# Altium schematic sheet REUN.468349.001_PSU_Board_3V3_Logic.SchDoc
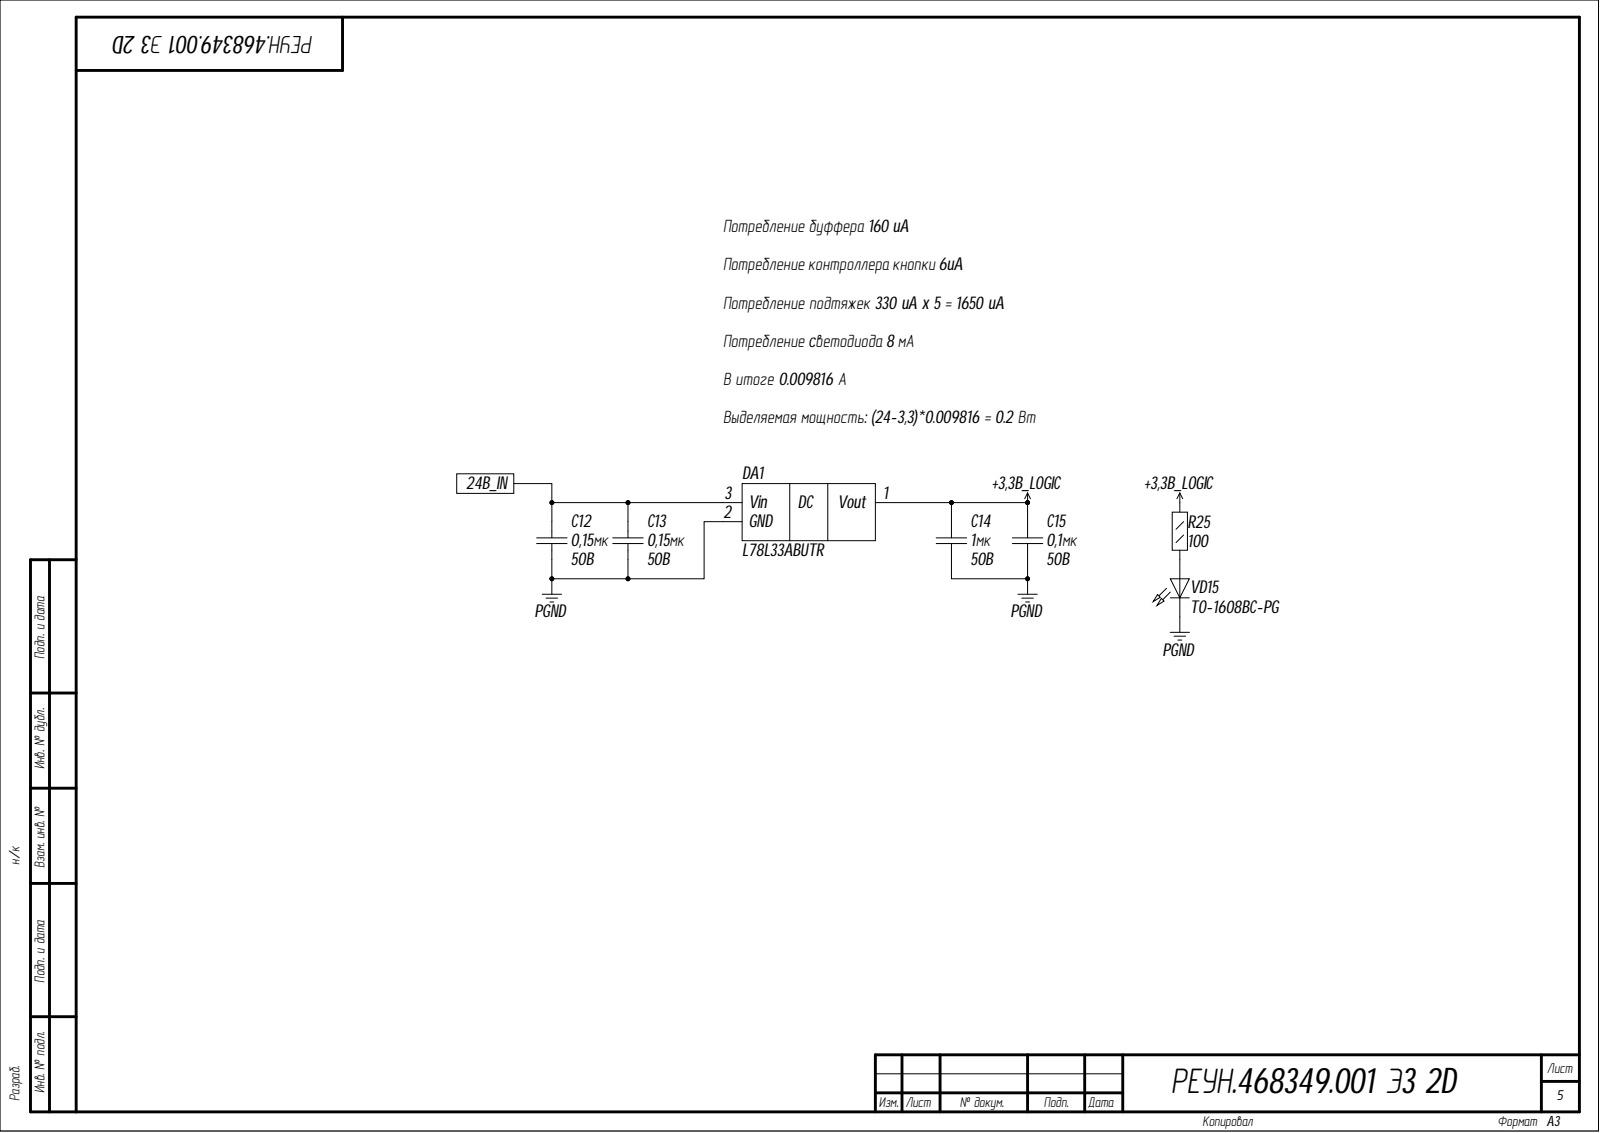
[diagram p0: REUN.468349.001_PSU_Board_3V3_Logic.SchDoc]

## Parts
C12  0,15мк  Voltage: 50B  [C0805]
C13  0,15мк  Voltage: 50B  [C0805]
C14  1мк  Voltage: 50B  [C0805]
C15  0,1мк  Voltage: 50B  [C0805]
DA1  L78L33ABUTR  [SOT-89-3]
R25  value 100  [R0805]  (hidden comment: Resistor_0,125Вт)
VD15  TO-1608BC-PG  [L_0603_GREEN]

## Ports
24B_IN

## Nets
+3,3B_LOGIC: C14.2 C15.2 DA1.1 R25.2
PGND: C12.2 C13.2 C14.1 C15.1 DA1.2 VD15.1
24B_IN: C12.1 C13.1 DA1.3 ; port 24B_IN
NetR25_1: R25.1 VD15.2

## Library data of the parts
C12 C13 = cap142: Конденсатор; Manufacture: Murata; Part Name: Ceramic; Part Number: GRM21BR71H154K; Database Table Name: Capacitors$; TKE: X7R; Component Kind: Standard; id: cap142; Library Name: MOP44.DbLib; Value: 0,15мк
C14 = cap151: Конденсатор; Manufacture: Murata; Part Name: Ceramic; Part Number: GRM21BR71H105KA12L; Database Table Name: Capacitors$; TKE: X7R; Component Kind: Standard; id: cap151; Library Name: MOP44.DbLib; Value: 1мк
C15 = cap141: Конденсатор; Manufacture: Murata; Part Name: Ceramic; Part Number: GRM21BR71H104KA01K; Database Table Name: Capacitors$; TKE: X7R; Component Kind: Standard; id: cap141; Library Name: MOP44.DbLib; Value: 0,1мк
DA1 = pwr180: Cтабилизатор напряжения (+3,3В; 0.1А), 4%, (-40...+125C); Manufacturer: ST Microelectronics; Part Name: Positive voltage regulators; Current: 100mA; Database Table Name: PowerTransducer$; Dropout Voltage: 2V; Input Voltage: 30B; Output Voltage: 3,3B; Quiescent current: 6mA; Component Kind: Standard; id: pwr180; Library Name: MOP44.DbLib; Part Number: L78L33ABUTR; Type: Linear
R25 = res49: Резистор; Manufacture: Yageo; Part Number: RC0805FR-07100RL; Accuracy: 0.01; Database Table Name: Resistor$; Component Kind: Standard; id: res49; Library Name: MOP44.DbLib; Part Name: Resistor; Power: 0,125Вт
VD15 = diode43: Светодиод; Manufacture: OASISTEK; Part Name: LED green 0603; Database Table Name: Diode$; Component Kind: Standard; id: diode43; Library Name: MOP44.DbLib; Part Number: TO-1608BC-PG
